# Altium schematic sheet Tiny Mic.SchDoc
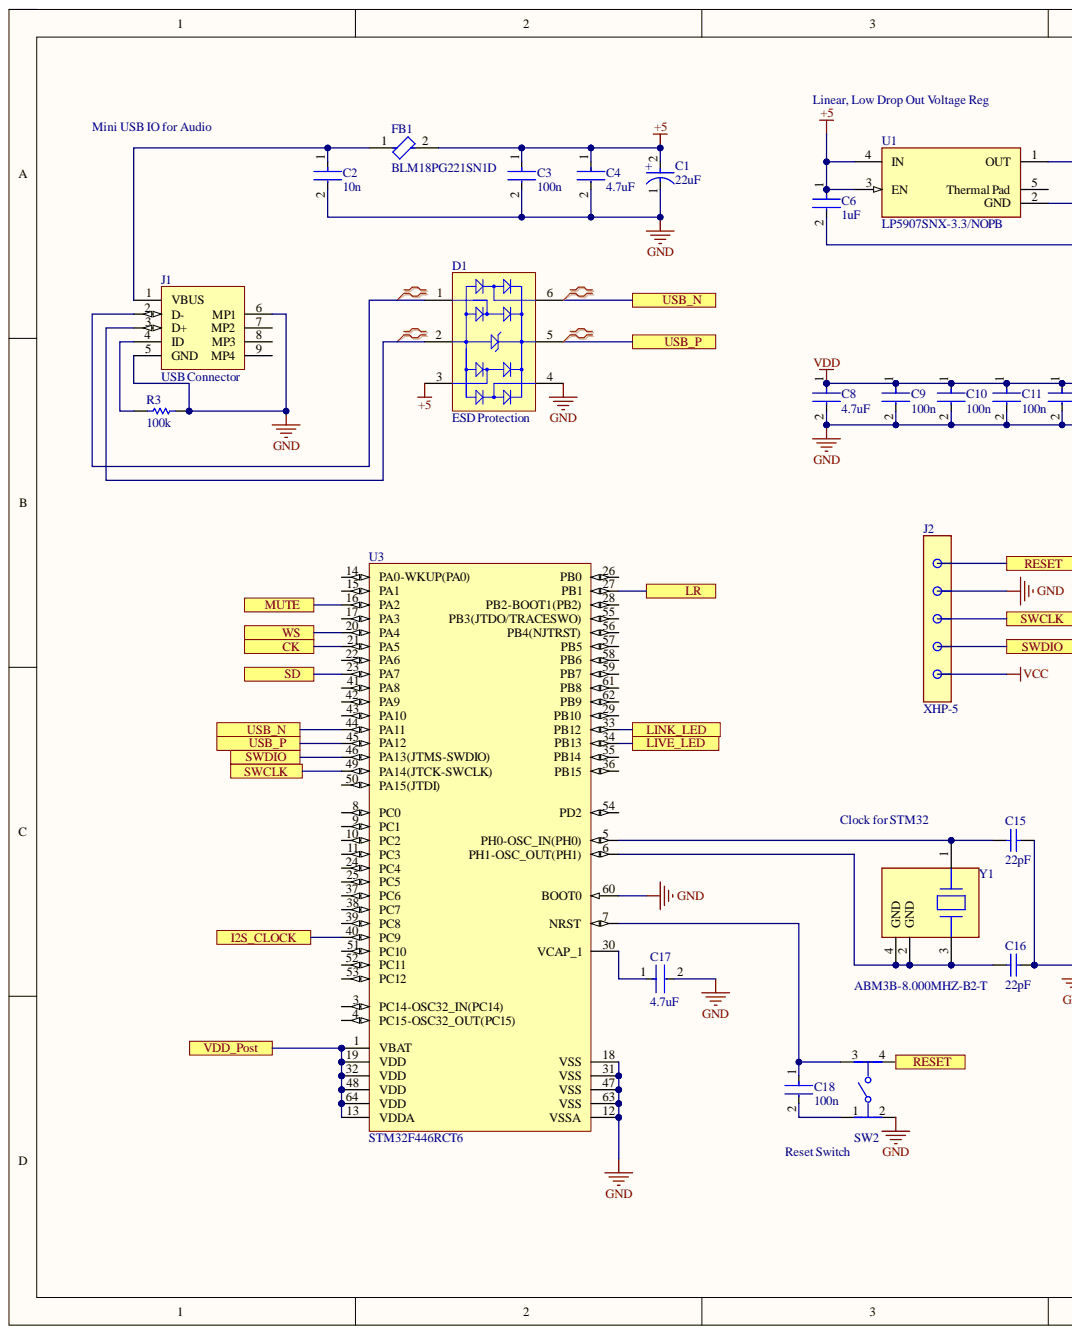
[diagram p0: Tiny Mic.SchDoc, left]
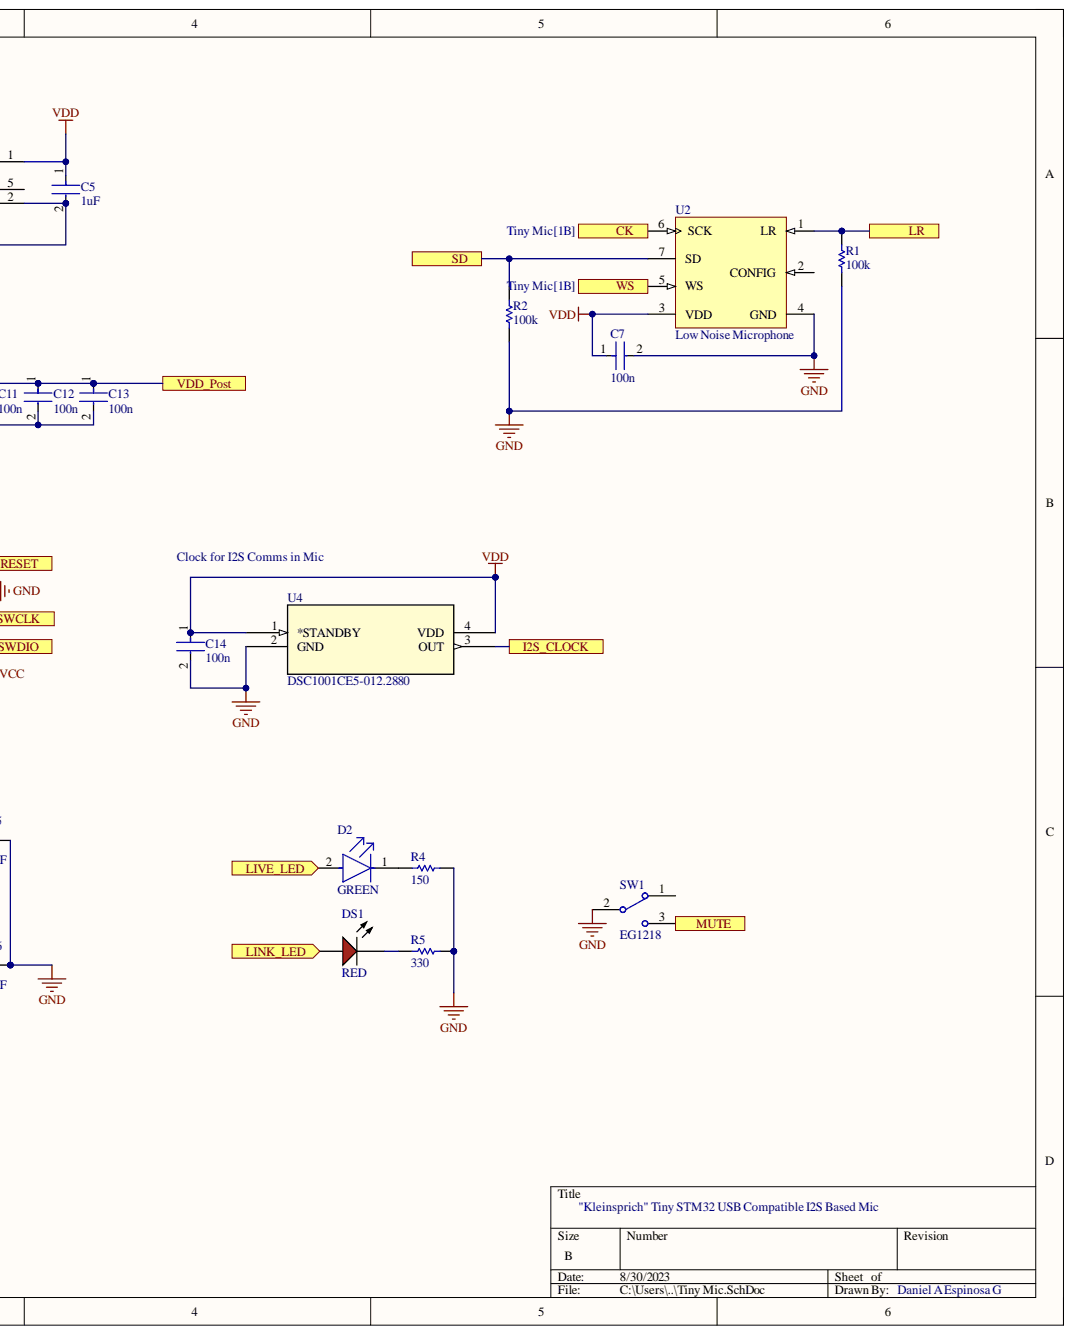
[diagram p1: Tiny Mic.SchDoc, right]

## Parts
C1  22uF  [FP-TAJC-MFG]
C2  10n  [FP-C0805C-DN-MFG]
C3  100n  [FP-C1206C-EB-MFG]
C4  4.7uF  [FP-C1005-050-0_15-MFG]
C5  1uF  [FP-GRM15-0_1-MFG]
C6  1uF  [FP-GRM15-0_1-MFG]
C7  100n  [FP-C1206C-EB-MFG]
C8  4.7uF  [FP-C1005-050-0_15-MFG]
C9  100n  [FP-C1206C-EB-MFG]
C10  100n  [FP-C1206C-EB-MFG]
C11  100n  [FP-C1206C-EB-MFG]
C12  100n  [FP-C1206C-EB-MFG]
C13  100n  [FP-C1206C-EB-MFG]
C14  100n  [FP-C1206C-EB-MFG]
C15  22pF  [CAPC1005X55X25NL05T10]
C16  22pF  [CAPC1005X55X25NL05T10]
C17  4.7uF  [FP-C1005-050-0_15-MFG]
C18  100n  [FP-C1206C-EB-MFG]
D1  ESD Protection  [STM-SOT23-6L_V]
D2  GREEN  [FP-LTST-C190GKT-MFG]
DS1  RED  [AVAG-HSMX-C150_V]
FB1  BLM18PG221SN1D  [FP-BLM18-0_15-t0_8-IPC_B]
J1  USB Connector  [MOLX-67503-1020_V]
J2  XHP-5  [FP-XHP-5-MFG]
R1  100k  [RESC1609X55X30ML10T20]
R2  100k  [RESC1609X55X30ML10T20]
R3  100k  [RESC1609X55X30ML10T20]
R4  150  [RESC1608X55X25ML10T15]
R5  330  [RESC1608X55X30LL15T15]
SW1  EG1218  [ESWI-EG1218_V]
SW2  Category: Switch  [FP-FSM2JSMAA-MFG]
U1  LP5907SNX-3.3/NOPB  [FP-DQN0004A-MFG]
U2  Low Noise Microphone  [INSE-ICS-43432_V]
U3  STM32F446RCT6  [STM-LQFP64_L]
U4  DSC1001CE5-012.2880  [CDFN4_3P2X2P5_MCH]
Y1  ABM3B-8.000MHZ-B2-T  [FP-ABM3B-MFG]

## Ports
CK, I2S_CLOCK, LINK_LED, LIVE_LED, LR, MUTE, RESET, SD, SWCLK, SWDIO, USB_N, USB_P, VDD_Post, WS

## Nets
+5: C1.2 C3.1 C4.1 C6.1 D1.3 FB1.2 U1.3(EN) U1.4(IN)
GND: C1.1 C2.2 C3.2 C4.2 C7.2 C8.2 C9.2 C10.2 C11.2 C12.2 C13.2 C14.2 C15.2 C16.2 C17.2 D1.4 J1.5(GND) J1.6(MP1) R1.1 R2.1 R3.2 R4.2 R5.2 SW1.2 SW2.2 U2.4(GND) U3.12(VSSA) U3.18(VSS) U3.31(VSS) U3.47(VSS) U3.60(BOOT0) U3.63(VSS) U4.2(GND)
CK: U2.6(SCK) U3.21(PA5) ; port CK
I2S_CLOCK: U3.40(PC9) U4.3(OUT) ; port I2S_CLOCK
LINK_LED: U3.33(PB12) ; port LINK_LED
LIVE_LED: U3.34(PB13) ; port LIVE_LED
LR: R1.2 U2.1(LR) U3.27(PB1) ; port LR
MUTE: SW1.3 U3.16(PA2) ; port MUTE
RESET: SW2.4 ; port RESET
SD: R2.2 U2.7(SD) U3.23(PA7) ; port SD
SWCLK: U3.49(PA14(JTCK-SWCLK)) ; port SWCLK
SWDIO: U3.46(PA13(JTMS-SWDIO)) ; port SWDIO
USB_N: D1.6 U3.44(PA11) ; port USB_N
USB_P: D1.5 U3.45(PA12) ; port USB_P
VDD: C5.1 C7.1 C8.1 C9.1 C10.1 C11.1 C12.1 C13.1 C14.1 U1.1(OUT) U2.3(VDD) U3.1(VBAT) U3.13(VDDA) U3.19(VDD) U3.32(VDD) U3.48(VDD) U3.64(VDD) U4.1(*STANDBY) U4.4(VDD) ; port VDD_Post
WS: U2.5(WS) U3.20(PA4) ; port WS
NetC2_1: C2.1 FB1.1 J1.1(VBUS)
NetC5_2: C5.2 C6.2 U1.2(GND)
NetC15_1: C15.1 U3.5(PH0-OSC_IN(PH0)) Y1.1
NetC16_1: C16.1 U3.6(PH1-OSC_OUT(PH1)) Y1.2(GND) Y1.3 Y1.4(GND)
NetC17_1: C17.1 U3.30(VCAP_1)
NetC18_1: C18.1 SW2.3 U3.7(NRST)
NetC18_2: C18.2 SW2.1
NetD1_1: D1.1 J1.2(D-)
NetD1_2: D1.2 J1.3(D+)
NetD2_1: D2.1 R4.1
NetDS1_2: DS1.2 R5.1
NetJ1_4: J1.4(ID) R3.1
VCC:

Not connected: D2 2; DS1 1; J1 7(MP2) 8(MP3) 9(MP4); SW1 1; U1 5(Thermal_Pad); U2 2(CONFIG); U3 3(PC14-OSC32_IN(PC14)) 4(PC15-OSC32_OUT(PC15)) 8(PC0) 9(PC1) 10(PC2) 11(PC3) 14(PA0-WKUP(PA0)) 15(PA1) 17(PA3) 22(PA6) 24(PC4) 25(PC5) 26(PB0) 28(PB2-BOOT1(PB2)) 29(PB10) 35(PB14) 36(PB15) 37(PC6) 38(PC7) 39(PC8) 41(PA8) 42(PA9) 43(PA10) 50(PA15(JTDI)) 51(PC10) 52(PC11) 53(PC12) 54(PD2) 55(PB3(JTDO/TRACESWO)) 56(PB4(NJTRST)) 57(PB5) 58(PB6) 59(PB7) 61(PB8) 62(PB9)

## Library data of the parts
C1 = CMP-04424-000242-1: 22uF 25V  ±10% 1.4Ω 2312  SMD Tantalum Capacitor  TAJC226K025RNJ; Dissipation Factor: 6%; ESR (Equivalent Series Resistance): 1.4R; Leakage Current: 5.5uA; Polarity: Polar; Capacitance: 22uF; Case Code (Imperial): 2312; Case Code (Metric): 6032; Case/Package: 2412; Component Kind: Standard; Construction: Flat; Depth: 3.2mm; Dielectric Material: Tantalum; Features: General Purpose; Height: 2.6mm; Height - Seated (Max): 0.11inch; Lead Free: Lead Free; Length: 6mm; Library Name: Altium Content Vault; Max Operating Temperature: 125°C; Min Operating Temperature: -55°C; Mount: Surface Mount; Number of Pins: 2; Package Quantity: 1; Packaging: Tape and Reel; Radiation Hardening: No; REACH SVHC: No SVHC; RoHS Compliant: Yes; Series: TAJ; Termination: SMD/SMT; Tolerance: 10%; Voltage: 25V; Voltage Rating: 25V; Voltage Rating (DC): 25V; Width: 3.2mm
C2 = CMP-2007-00259-2: CAP CER 10000PF 200V X7R 0805 C0805C103K2RACTU; Manufacturer: KEMET; Capacitance: 10,000 pF; Material: Ceramic; Temperature Coefficient: 30ppm/°C; Tolerance: 0.1; Value: 10,000 pF; Automotive: No; Category: Cap; Component Kind: Standard; ComponentLink2Description: Datasheet; ComponentLink2URL: https://content.kemet.com/datasheets/KEM_C1003_C0G_SMD.pdf; Device Class L1: Passive Components; Device Class L2: Capacitors; Device Class L3: Ceramic Capacitors; Digikey Description: CAP CER 10000PF 200V X7R 0805; Height: 0.88mm; Ipc Land Pattern Name: CAPC200125X78; Lead Free: Yes; Library Name: Altium Content Vault; Manufacturer Part Number: C0805C103K2RACTU; Mouser Description: KEMET - C0805C103K2RACTU - CAP, MLCC, X7R, 10NF, 200V, 0805; Octopart: https://octopart.com/c0805c103k2ractu-kemet-9138347; Package: C0805C-DN; RoHS: TRUE; Temperature: -55°C to +125°C; Temperature Characteristic: X7R; Temperature Range High: +125°C; Temperature Range Low: -55°C; Voltage Rating: 200 V
C3 C7 C9-C14 C18 = CMP-1037-04222-2: CAP CER 0.1UF 200V 10% X7R 1206 C1206C104K2RACTU; Manufacturer: KEMET; Automotive Grade: Grade 0; Capacitance: 0.10 μF; Material: Ceramic; Temperature Coefficient: 15%; Tolerance: 0.1; Value: 0.10 μF; Automotive: Yes; Category: Cap; Component Kind: Standard; ComponentLink2Description: Datasheet; ComponentLink2URL: https://content.kemet.com/datasheets/KEM_C1007_X8R_ULTRA_150C_SMD.pdf; Device Class L1: Passive Components; Device Class L2: Capacitors; Device Class L3: Ceramic Capacitors; Digikey Description: CAP CER 0.1UF 200V 10% X7R 1206; Height: 0.88mm; Ipc Land Pattern Name: CAPC320160X78; Lead Free: Yes; Library Name: Altium Content Vault; Manufacturer Part Number: C1206C104K2RACTU; Mouser Description: Multilayer Ceramic Capacitors MLCC - SMD/SMT 200volts 0.1uF X7R 10%; Octopart: https://octopart.com/c1206c104k2ractu-kemet-9138344; Package: C1206C-EB; RoHS: TRUE; Temperature: -55°C to +150°C; Temperature Characteristic: X7R; Temperature Range High: +150°C; Temperature Range Low: -55°C; Voltage Rating: 200 V
C4 C8 C17 = CMP-2000-05997-2: CAP CER 4.7UF 10V X5R 0402 C1005X5R1A475K050BC; Dielectric Material: Ceramic; Tolerance: 10%; Capacitance: 4.7uF; Case Code (Imperial): 0402; Case Code (Metric): 1005; Case/Package: 0402; Component Kind: Standard; Dielectric: X5R; Lead Free: Lead Free; Length: 0.039inch; Library Name: Altium Content Vault; Max Operating Temperature: 85°C; Min Operating Temperature: -55°C; Mount: Surface Mount; Package Quantity: 10000; Packaging: Cut Tape; REACH SVHC: No SVHC; RoHS Compliant: Yes; Series: C; Thickness: 0.022inch; Voltage Rating: 10V; Voltage Rating (DC): 10V; Width: 0.02inch
C5 C6 = CMP-2008-04556-2: CAP CER 1UF 10V X5R 0402 GRM155R61A105KE15D; Manufacturer: Murata; Material: Ceramic; Temperature Coefficient: 15%; Tolerance: 10%; Automotive: No; Capacitance: 1uF; Category: Cap; Component Kind: Standard; ComponentLink2Description: Datasheet; ComponentLink2URL: https://search.murata.co.jp/Ceramy/image/img/A01X/G101/ENG/GRM155R61A105KE15-01A.pdf; Device Class L1: Passive Components; Device Class L2: Capacitors; Device Class L3: Ceramic Capacitors; Digikey Description: CAP CER 1UF 10V X5R 0402; Height: 0.55mm; Ipc Land Pattern Name: CAPC10050X50; Lead Free: Yes; Library Name: Altium Content Vault; Manufacturer Part Number: GRM155R61A105KE15D; Mouser Description: 0402 1 uF 10 V ±10% Tolerance X5R Surface Mount Multilayer Ceramic Capacitor; Octopart: https://octopart.com/grm155r61a105ke15d-murata-197442; Package: GRM15-0.1; RoHS: TRUE; Temperature: -55°C to +85°C; Temperature Characteristic: X5R; Temperature Range High: +85°C; Temperature Range Low: -55°C; Value: 1uF; Voltage Rating: 10V
C15 C16 = CMP-2008-04304-1: GRM1555C1H220JZ01D; Manufacturer: Murata; Component Kind: Standard; Library Name: Altium Content Vault; Part Number: GRM1555C1H220JZ01D
D1 = CMP-2000-05378-1: Very low capacitance ESD protection, 3.5 pF, -40 to 125 degC, 6-Pin SOT23, RoHS, Tape and Reel USBLC6-2SC6; Manufacturer: STMicroelectronics; Capacitance Value: 3.5pF; Clamping Voltage: 17V; Configuration: Dual; Direction Type: Uni-Directional; Leakage Current: 0.15uA; Number of Elements: 1; Peak Pulse Current: 5A; Product Depth (mm): 1.75mm; Product Height (mm): 1.3mm; Product Length (mm): 3.05mm; Rad Hardened: No; Reverse Breakdown Voltage: 6V; Reverse Stand-off Voltage: 5V; Suppressor Type: DiodeArrays; Test Current (It): 1mA; Clamping Voltage (Max): 17V; Component Kind: Standard; Datasheet URL: http://www.st.com/st-web-ui/static/active/en/resource/technical/document/datasheet/CD00050750.pdf; Datasheet Version: Rev. 5, 10/2011; Leakage Current (Max): 0.15uA; Library Name: Altium Content Vault; Manufacturer URL: http://www.st.com/; Mounting: SurfaceMount; Mounting Style: SurfaceMount; Operating Temp Range: -40Cto125C; Operating Temperature Classification: Automotive; Operating Temperature Max Deg. C: 125C; Operating Temperature Min Deg. C: -40C; Package / Case: SOT-23; Package Description: 6-Pin Small Outline Transistor, Body 2.925 x 1.625 mm, Pitch 0.95 mm; Package Reference: SOT23-6L; Packaging: TapeandReel; Pin Count: 6; Polarity: Uni-Directional; Working Voltage: 5V; Working Voltage (Max): 5V
D2 = CMP-22017-000005-2: LED GREEN CLEAR CHIP SMD LTST-C190GKT; Dominant Wavelength: 569nm; Forward Current: 30mA; Forward Voltage: 2.1V; Lens Color: Colorless; Luminous Intensity: 6mcd; Number of Elements: 1; Power Dissipation: 100mW; Reverse Voltage: 5V; Test Current: 10mA; Viewing Angle: 130°; Voltage: 2V; Wavelength: 565nm; Case Code (Imperial): 0603; Case Code (Metric): 1608; Case/Package: 0603; Color: Green; Component Kind: Standard; Depth: 0.8mm; Height: 0.8mm; Illumination Color: Green; Lead Free: Lead Free; Length: 1.6mm; Lens Style: Clear; Lens Transparency: Clear; Library Name: Altium Content Vault; Max Operating Temperature: 85°C; Min Operating Temperature: -55°C; Mount: Surface Mount; Number of LEDs: 1; Number of Pins: 2; Package Quantity: 1; Packaging: Tape and Reel; Power Rating: 100mW; Radiation Hardening: No; Width: 0.8mm
DS1 = CMP-2000-06426-1: LED Uni-Color Red, 65 mW, 25 mA, -40 to 85 degC, 2-Pin SMD, RoHS, Tape and Reel HSMH-C150; Manufacturer: Avago; Chip Material: GaAlAs; DELETED: Compliant; Dominant Wave Length: 639nm; Forward Current: 25mA; Forward Voltage: 2.6V; High Brightness: No; Lens Type: ColorlessDiffused; Lum Intensity: 17mcd; Main Category: ChipLED; Number of  Elements: 1; Peak Wavelength: 660nm; Power Dissipation: 78W; Product Depth (mm): 1.6mm; Product Height (mm): 1.1mm; Product Length (mm): 3.2mm; Reverse Voltage: 5V; Shape Type: Rectangular; Test Current (It): 20mA; Viewing Angle: 170deg; Component Kind: Standard; Datasheet URL: http://media.digikey.com/pdf/Data%20Sheets/Avago%20PDFs/HSMz-Czzz.pdf; Datasheet Version: 03/2012; Emitting Color: Red; Lens Dimensions: 2x1.6x0.6mm; Library Name: Altium Content Vault; Luminous Intensity: 17mcd; Manufacturer URL: http://www.avagotech.com/; Mounting: SurfaceMount; Mounting Technology: SurfaceMount; Operating Temp Range: -40Cto85C; Operating Temperature Classification: Industrial; Package Description: 2-Pin Surface Mount Device, Body 3.2 x 1.6 mm; Package Reference: HSMX-C150; Package Type: ChipLed; Packaging: TapeandReel; Pin Count: 2; Rad Hardened: No; Type: Uni-Color
FB1 = CMP-0686-00569-3: Chip Ferrite Bead, 0603, 220Ω @ 100MHz, 0.1Ω, 25%, 1.4A; Manufacturer Series: BLM18P; DC Resistance (DCR): 100mR; ESR (Equivalent Series Resistance): 220R; Material: Steel; Number of Circuits: 1; Shielding: Unshielded; Case Code (Imperial): 0603; Case Code (Metric): 1608; Case/Package: 0603; Component Kind: Standard; Core Material: Ferrite; Current Rating: 1.4A; DC Current: 1.4A; DC Resistance-Max: 100mR; Depth: 0.8mm; Height: 0.8mm; Impedance: 220R; Lead Free: Lead Free; Length: 1.6mm; Library Name: Altium Content Vault; Max Current Rating: 1.4A; Max DC Current: 1.4A; Max Operating Temperature: 125°C; Min Operating Temperature: -55°C; Number of Functions: 1; Number of Lines: 1; Number of Pins: 2; Package Quantity: 1; Packaging: Tape and Reel; Radiation Hardening: No; REACH SVHC: No SVHC; Resistance: 100mR; RoHS Compliant: Yes; Series: BLM18P; Termination: SMD/SMT; Test Frequency: 100MHz; Tolerance: 25%; Weight: 7.1E-05oz; Width: 0.8mm
J1 = CMP-0411-00002-1: USB, MINI, SMD, RA KME04-USBMU03A01 67503-1020; Manufacturer: Molex; Component Kind: Standard; ComponentLink1Description: Manufacturer URL; ComponentLink1URL: http://www.molex.com/; ComponentLink2Description: Datasheet; ComponentLink2URL: http://www.molex.com/pdm_docs/sd/675031020_sd.pdf; DatasheetVersion: Rev F, 06/2009; Library Name: Altium Content Vault; Mounting Technology: Surface Mount; PackageDescription: Mini USB Connector, SMT, Righer Angle; PackageReference: 67503-1020; PartNumber: 67503-1020; Terminal Pitch: 0.8 mm
J2 = CMP-17439-000071-1: CONN HOUSING 2.5MM 5POS; Connector Type: Receptacle; Contact Resistance: 10mR; Current Rating: 3A; Insulation Resistance: 1GR; Max Voltage Rating (AC): 250V; Number of Contacts: 5; Wire Gauge (Max): 30AWG; Wire Gauge (Min): 22AWG; Color: Natural; Component Kind: Standard; Contact Material: Bronze; Contact Type: Female Socket; Depth: 7.75mm; Gender: Receptacle; Height: 0.25inch; Housing Color: White; Housing Material: Polyamide; Lead Free: Lead Free; Lead Pitch: 2.5mm; Length: 14.8mm; Library Name: Altium Content Vault; Max Current Rating: 3A; Max Operating Temperature: 85°C; Max Voltage Rating (DC): 250V; Min Operating Temperature: -25°C; Mount: Free Hanging; Number of Positions: 5; Number of Rows: 1; Orientation: Straight; Packaging: Bag; Pitch: 2.5mm; REACH SVHC: No SVHC; Series: XH; Termination: Crimp; Voltage Rating (AC): 250V; Weight: 0.0625lb; Width: 5.7mm; Wire/Cable Gauge: 22AWG
R1-R3 = CMP-2000-03686-1: TNPW0603100KBEEN; Manufacturer: Vishay Dale; Component Kind: Standard; Library Name: Altium Content Vault; Part Number: TNPW0603100KBEEN
R4 = CMP-1659-00057-1: Chip Resistor, 150 Ohm, +/- 1%, 0.1 W, -55 to 155 degC, 0603 (1608 Metric), RoHS, Tape and Reel RC0603FR-07150RL; Case\Package: Radial; Forward Voltage: 2.2 V; Illumination Colour: Green; Lens Style: Clear; Luminous Intensity: 200 mcd; Viewing Angle: 20 degrees; Colour: Green; Component Kind: Standard; ComponentLink1Description: Manufacturer URL; ComponentLink1URL: http://www.yageo.com/; ComponentLink2Description: Datasheet; ComponentLink2URL: http://www.yageo.com/documents/recent/PYu-RC_Group_51_RoHS_L_04.pdf; DatasheetVersion: Rev. 4, 02/2016; Height: 8.6 mm; Library Name: Altium Content Vault; Mount: Through Hole; Nominal Vf: 2.2 V; Package Quantity: 1000; PackageDescription: 2-Pin Surface Mount Device, Body 1.6 x 0.8 mm; PackageReference: 0603; Packaging: Bulk; RoHSCompliant: true
R5 = CMP-2000-00435-2: Chip Resistor, 330 Ohm, +/- 1%, 0.1 W, -55 to 155 degC, 0603 (1608 Metric), RoHS, Tape and Reel ERJ-3EKF3300V; Current Rating: 500 mA; Gender: Male; Mating Cycles: 10000; Voltage Rating: 10 V; Component Kind: Standard; ComponentLink1Description: Manufacturer URL; ComponentLink1URL: http://www.panasonic.com/; ComponentLink2Description: Datasheet; ComponentLink2URL: http://industrial.panasonic.com/cdbs/www-data/pdf/RDA0000/AOA0000C86.pdf; Contact Finish: Gold; Contact Material: Copper; Contact Plating: Gold; DatasheetVersion: Apr-15; Height: 1.45 mm; Lead Pitch: 1.1 mm; Length: 13.7 mm; Library Name: Altium Content Vault; Max Operating Temperature: 85 degC; Min Operating Temperature: -25 degC; Mount: Surface Mount; Package Quantity: 1500; PackageDescription: 2-Pin Surface Mount Device, Body 1.6 x 0.85 mm; PackageReference: 0603; Packaging: Tape and Reel; Pins: 8; REACH SVHC: No SVHC; RoHSCompliant: true; Width: 1.45 mm
SW1 = CMP-1696-00002-1: Slide Switch, 500 V, -20 to 70 degC, 3-Pin THD, RoHS; Case\Package: SOT-23-5; Dropout Voltage: 300 mV; Max Input Voltage: 8 V; Max Ouput Current: 150 mA; Max Power Dissipation: 250 mW; Min Input Voltage: 4.3 V; Nominal Output Voltage: 3.3 V; Outputs: 1; Component Kind: Standard; ComponentLink1Description: Manufacturer URL; ComponentLink1URL: http://www.e-switch.ch/en/; ComponentLink2Description: Datasheet; ComponentLink2URL: https://www.e-switch.com/system/asset/product_line/data_sheet/119/EG.pdf; DatasheetVersion: Oct-15; Height: 1.3 mm; Length: 3 mm; Library Name: Altium Content Vault; Max Operating Temperature: 85 degC; Min Operating Temperature: -40 degC; Mount: Surface Mount; Output Current: 150 mA; Output Voltage: 3.3 V; Package Quantity: 3000; PackageDescription: 3-Pin Through Hole Device, Body 11.6 x 4 mm, Pitch 2.5 mm; PackageReference: EG1218; Packaging: Tape and Reel; Pins: 5; Power Rating: 250 mW; Radiation Hardening: No; REACH SVHC: No SVHC; RoHSCompliant: true; Width: 1.8 mm
SW2 = CMP-03402-000003-1: SWITCH TACTILE SPST-NO 0.05A 24V FSM2JSMAA; Manufacturer: TE Connectivity; Contact Current Rating: 50mA; Contact Resistance: 100mΩ; Electromechanical Life: 100000Cycles; Operating Force: 260gf; Standoff Height: 0.05mm; Automotive: No; Component Kind: Standard; ComponentLink2Description: Datasheet; ComponentLink2URL: https://www.te.com/commerce/DocumentDelivery/DDEController?Action=showdoc&DocId=Customer+Drawing%7F2-1437565-7%7FV%7Fpdf%7FEnglish%7FENG_CD_2-1437565-7_V.pdf%7F2-1437565-8; Device Class L1: Electromechanical; Device Class L2: Switches; Device Class L3: Pushbutton Switches; Digikey Description: SWITCH TACTILE SPST-NO 0.05A 24V; Height: 4.59mm; Lead Free: Yes; Library Name: Altium Content Vault; Manufacturer Part Number: FSM2JSMAA; Mount: Surface Mount; Mouser Description: Tactile Switches TACTILE 260G FORCE S; Octopart: https://octopart.com/fsm2jsmaa-te+connectivity-41720620; Package: 0; RoHS: TRUE; Temperature: -35°C to +85°C; Temperature Range High: +85°C; Temperature Range Low: -35°C; Throw Configuration: SPST-NO; Voltage Rating Dc: 24V
U1 = CMP-04917-000059-2: None; Accuracy: 2%; Dropout Voltage: 250mV; Max Input Voltage: 5.5V; Max Output Current: 250mA; Min Input Voltage: 2.2V; Nominal Output Voltage: 3.3V; Number of Outputs: 1; Output Type: Fixed; Polarity: Positive; Power Supply Rejection Ratio (PSRR): 82dB; Quiescent Current: 250uA; Component Kind: Standard; Contact Plating: Gold; Lead Free: Lead Free; Library Name: Altium Content Vault; Max Operating Temperature: 125°C; Min Operating Temperature: -40°C; Mount: Surface Mount; Number of Pins: 4; Number of Regulators: 1; Output Current: 250mA; Output Voltage: 3.3V; Output Voltage Accuracy: 2%; Packaging: Tape and Reel; Radiation Hardening: No; REACH SVHC: No SVHC; RoHS Compliant: Yes; Tolerance: 2%
U2 = CMP-2000-06439-1: Low Noise Microphone with I2S Digital Output, 1 mA, -40 to 85 degC, 7-Pin SMD, RoHS, Tape and Reel ICS-43432; Manufacturer: InvenSense; Current - Supply: 1.5mA; Direction: Omnidirectional; Frequency Range: 50Hz~20kHz; Output Type: Digital,I²S; Port Location: Bottom; S/N Ratio: 65dB; Seal Rating: None; Sensitivity: -26dB±1dB@94dBSPL; Shape: Rectangular; Voltage Range: 1.62~3.63V; Category: AudioProducts; Component Kind: Standard; Datasheet URL: https://www.invensense.com/wp-content/uploads/2015/02/ICS-43432-data-sheet-v1.3.pdf; Datasheet Version: Rev. 1.3, 04/2016; Family: Microphones; Height (Max): 1.10mm; Impedance: -; Library Name: Altium Content Vault; Manufacturer URL: http://www.invensense.com/; Mounting Technology: SurfaceMount; Package Description: 7-Pin Surface Mount Device, Body 4 x 3 mm, Pitch 0.65 mm, Height 1.1 mm; Package Reference: ICS-43432; Packaging: Tape&amp;Reel(TR); Series: -; Size / Dimension: 4.00mmLx3.00mmW; Termination: SolderPads; Type: MEMS(Silicon); Voltage - Rated: -
U3 = CMP-0243-00216-1: ARM Cortex-M4 32-bit MCU+FPU, 256 KB Flash, 128 KB Internal RAM, 50 I/Os, 64-Pin LQFP, -40 to 85 degC, Tray; D/A Converter: 2; FLASH Size (Prog) (kB): 256; I/Os (High Current): 50; Internal RAM Size (kB): 128; Operating Frequency(F) (Processor speed) (MHz): 180; Supply Voltage(Vcc) min (V): 1.7; Component Kind: Standard; ComponentLink1Description: Manufacturer URL; ComponentLink1URL: http://www.st.com/; ComponentLink2Description: Datasheet; ComponentLink2URL: http://www.st.com/st-web-ui/static/active/en/resource/technical/document/datasheet/DM00141306.pdf; Core: ARM Cortex-M4; DatasheetVersion: Rev. 6; Library Name: Altium Content Vault; Mounting Technology: Surface Mount; Package Description: LQFP64; Package Reference: 64-Pin Low-profile Quad Flat Package, Body 10 x 10 mm, Pitch 0.5 mm; Packing: Tray
U4 = DSC1001CE5-012.2880: 12.288 MHz XO (Standard) CMOS Oscillator 1.8V ~ 3.3V Standby (Power Down) 4-SMD, No Lead DSC1001CE5-012.2880; Mfr_Name: Microchip; Component Kind: Standard; Library Name: DSC1001CE5-012P2880.SchLib; RefDes: RefDes; Type: Type
Y1 = CMP-1688-00002-2: Crystal 8MHz ±20ppm 18pF SMD-4 5mm x 3.2mm; ESR (Equivalent Series Resistance): 200R; Frequency Stability: 50ppm; Case/Package: CSMD; Component Kind: Standard; Depth: 3.2mm; Frequency: 8MHz; Frequency Tolerance: 20ppm; Height: 0.043inch; Lead Free: Lead Free; Length: 5mm; Library Name: Altium Content Vault; Load Capacitance: 18pF; Max Operating Temperature: 70°C; Min Operating Temperature: -20°C; Mount: Surface Mount; Number of Pins: 4; Operating Frequency: 8 MHz; Package Quantity: 1000; Packaging: Tape and Reel; Resistor Type: Ceramic; Series: ABM3B; Series Resistance: 200R; Termination: SMD/SMT; Tolerance: 20ppm; Width: 0.126inch
